# Altium schematic sheet 05_interconnection.SchDoc(05_interconnection)
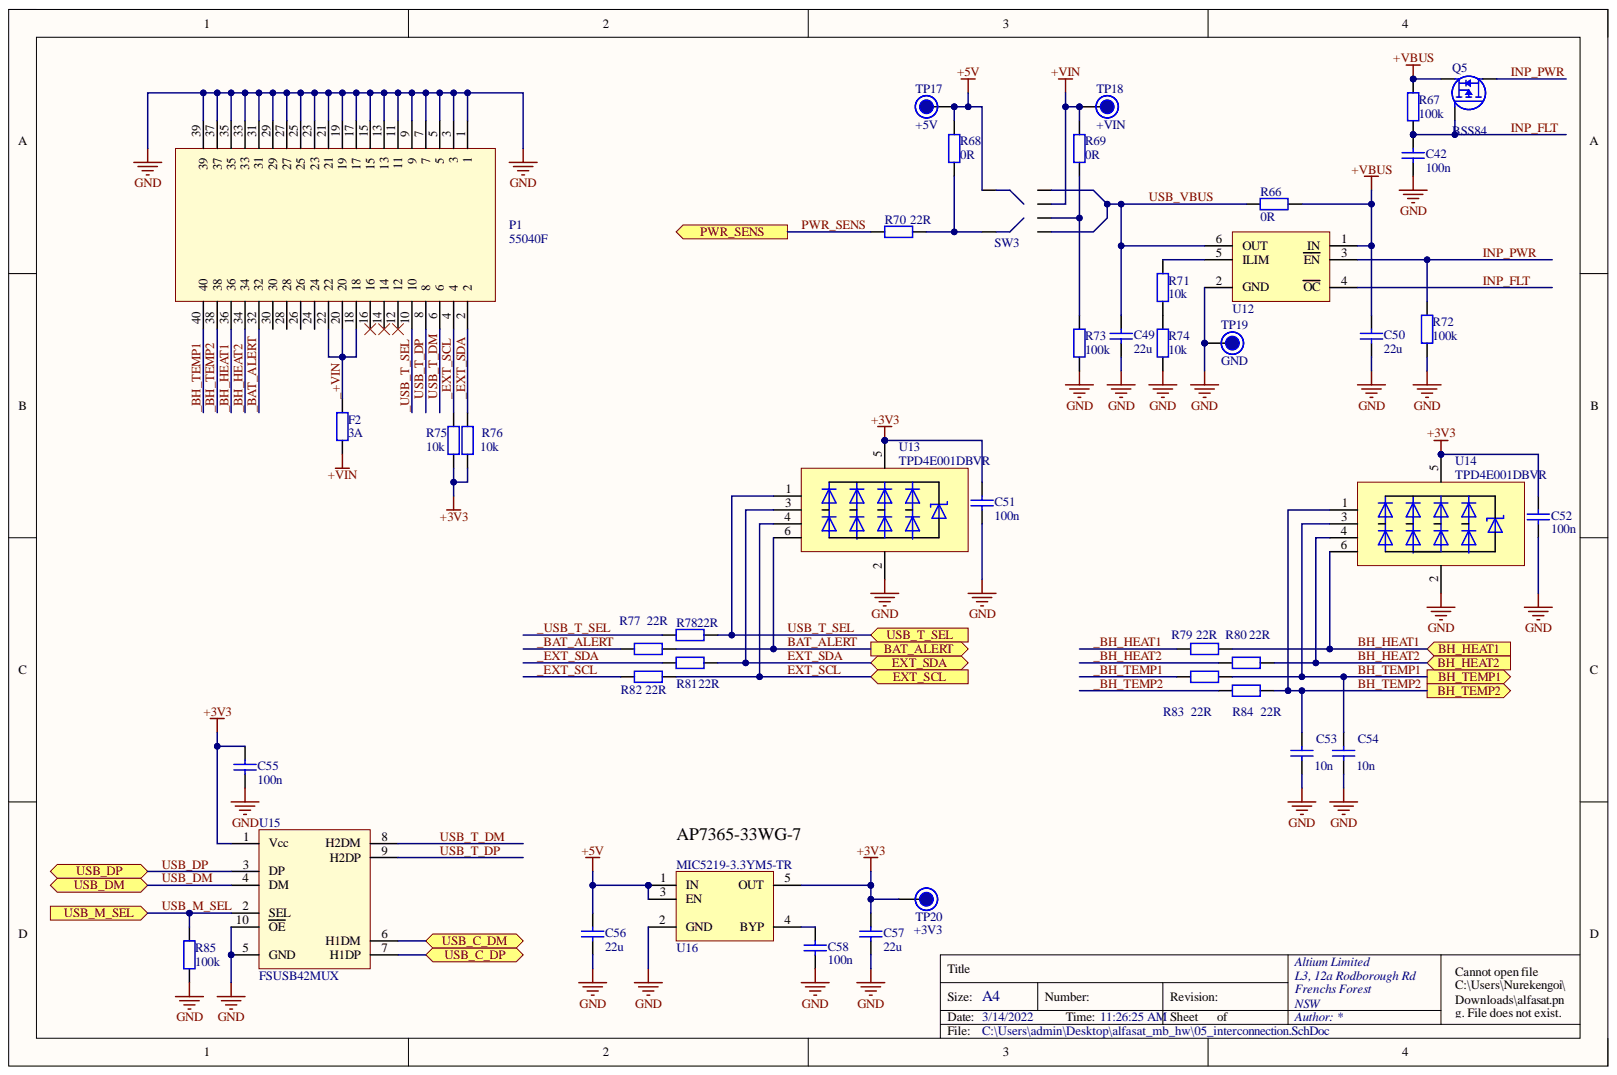
[diagram p0: 05_interconnection.SchDoc]

## Parts
C42  100n  [C0603_IPC_MD]
C49  22u  [C0805_IPC_MD]
C50  22u  [C0805_IPC_MD]
C51  100n  [C0603_IPC_MD]
C52  100n  [C0603_IPC_MD]
C53  10n  [C0603_IPC_MD]
C54  10n  [C0603_IPC_MD]
C55  100n  [C0603_IPC_MD]
C56  22u  [C0805_IPC_MD]
C57  22u  [C0805_IPC_MD]
C58  100n  [C0603_IPC_MD]
F2  3A  [F0603_IPC_MD]
P1  55040F  [HEADER_40PIN_12MM2]
Q5  BSS84  [SOT23]
R66  0R  [R0603_IPC_MD]
R67  100k  [R0603_IPC_MD]
R68  0R  [R0603_IPC_MD]
R69  0R  [R0603_IPC_MD]
R70  22R  [R0603_IPC_MD]
R71  10k  [R0603_IPC_MD]
R72  100k  [R0603_IPC_MD]
R73  100k  [R0603_IPC_MD]
R74  10k  [R0603_IPC_MD]
R75  10k  [R0603_IPC_MD]
R76  10k  [R0603_IPC_MD]
R77  22R  [R0603_IPC_MD]
R78  22R  [R0603_IPC_MD]
R79  22R  [R0603_IPC_MD]
R80  22R  [R0603_IPC_MD]
R81  22R  [R0603_IPC_MD]
R82  22R  [R0603_IPC_MD]
R83  22R  [R0603_IPC_MD]
R84  22R  [R0603_IPC_MD]
R85  100k  [R0603_IPC_MD]
SW3  K3-2245D-L1  [SWITCH_50mA_25V_V2]  (value not drawn)
TP17  +5V  [TestPoint_1M0]  (no BOM)
TP18  +VIN  [TestPoint_1M0]  (no BOM)
TP19  GND  [TestPoint_1M0]  (no BOM)
TP20  +3V3  [TestPoint_1M0]  (no BOM)
U12  BD2243G-GTR  [SOT23-6]  (value not drawn)
U13  TPD4E001DBVR  [SOT23-6]
U14  TPD4E001DBVR  [SOT23-6]
U15  FSUSB42MUX  [MSOP-10]
U16  MIC5219-3.3YM5-TR  [SOT23-5_(MT)]

## Ports
BAT_ALERT, BH_HEAT1, BH_HEAT2, BH_TEMP1, BH_TEMP2, EXT_SCL, EXT_SDA, PWR_SENS, USB_C_DM, USB_C_DP, USB_DM, USB_DP, USB_M_SEL, USB_T_SEL

## Nets
+3V3: C51.1 C52.1 C55.1 C57.1 R75.1 R76.1 TP20.0 U13.5 U14.5 U15.1(Vcc) U16.5(OUT)
+5V: C56.1 R68.2 SW3.2 TP17.0 U16.1(IN) U16.3(EN)
+VBUS: C50.1 Q5.2 R66.2 R67.2 U12.1(IN)
+VIN: F2.2 R69.2 SW3.3 TP18.0
GND: C42.2 C49.2 C50.2 C51.2 C52.2 C53.1 C54.1 C55.2 C56.2 C57.2 C58.2 P1.1 P1.3 P1.5 P1.7 P1.9 P1.11 P1.13 P1.15 P1.17 P1.19 P1.21 P1.23 P1.25 P1.27 P1.29 P1.31 P1.33 P1.35 P1.37 P1.39 R72.1 R73.1 R74.1 R85.1 TP19.0 U12.2(GND) U13.2 U14.2 U15.5(GND) U15.10(OE) U16.2(GND)
_+VIN: F2.1 P1.18 P1.20 P1.22
_BAT_ALERT: P1.32 R77.2
_BH_HEAT1: P1.36 R79.1
_BH_HEAT2: P1.34 R80.1
_BH_TEMP1: P1.40 R83.1
_BH_TEMP2: P1.38 R84.1
_EXT_SCL: P1.4 R75.2 R82.1
_EXT_SDA: P1.2 R76.2 R81.1
_USB_T_SEL: P1.10 R78.1
BAT_ALERT: R77.1 U13.6 ; port BAT_ALERT
BH_HEAT1: R79.2 U14.6 ; port BH_HEAT1
BH_HEAT2: R80.2 U14.4 ; port BH_HEAT2
BH_TEMP1: C54.2 R83.2 U14.3 ; port BH_TEMP1
BH_TEMP2: C53.2 R84.2 U14.1 ; port BH_TEMP2
EXT_SCL: R82.2 U13.4 ; port EXT_SCL
EXT_SDA: R81.2 U13.3 ; port EXT_SDA
INP_FLT: C42.1 Q5.1 R67.1 U12.4(OC)
INP_PWR: Q5.3 R72.2 U12.3(EN)
PWR_SENS: R70.2 ; port PWR_SENS
USB_C_DM: U15.6(H1DM) ; port USB_C_DM
USB_C_DP: U15.7(H1DP) ; port USB_C_DP
USB_DM: U15.4(DM) ; port USB_DM
USB_DP: U15.3(DP) ; port USB_DP
USB_M_SEL: R85.2 U15.2(SEL) ; port USB_M_SEL
USB_T_DM: P1.6 U15.8(H2DM)
USB_T_DP: P1.8 U15.9(H2DP)
USB_T_SEL: R78.2 U13.1 ; port USB_T_SEL
USB_VBUS: C49.1 R66.1 SW3.1 SW3.4 U12.6(OUT)
NetC58_1: C58.1 U16.4(BYP)
NetR68_1: R68.1 R70.1 SW3.5
NetR69_1: R69.1 R73.2 SW3.6
NetR71_1: R71.1 U12.5(ILIM)
NetR71_2: R71.2 R74.2

Not connected: P1 12 14 16 24 26 28 30

## Library data of the parts
C42 C51 C52 C55 C58 = CL10B104KO8NNNC: CAP CER 0.1UF 16V X7R 0603; Manufacturer: Samsung Electro-Mechanics; Component Kind: Standard; Dielectric: X7R; Manufacturer Part Number: CL10B104KO8NNNC; Supplier 1: Digi-Key; Supplier Part Number 1: 1276-1005-1-ND; Value: 100n; Voltage: 16V; Library Name: alfasat_parts_lib.IntLib
C49 C50 C56 C57 = CL21A226MOCLRNC: CAP CER 22UF 16V X5R 0805; Manufacturer: Samsung Electro-Mechanics; Component Kind: Standard; Dielectric: X5R; Manufacturer Part Number: CL21A226MOCLRNC; Supplier 1: Digi-Key; Supplier Part Number 1: 1276-6780-1-ND; Value: 22u; Voltage: 16V; Library Name: alfasat_parts_lib.IntLib
C53 C54 = CL10B103KB8NNNC: CAP CER 0.01UF 50V X7R 0603; Manufacturer: Samsung Electro-Mechanics; Component Kind: Standard; Dielectric: X7R; Manufacturer Part Number: CL10B103KB8NNNC; Supplier 1: Digi-Key; Supplier Part Number 1: 1276-1009-1-ND; Value: 10n; Voltage: 50V; Library Name: alfasat_parts_lib.IntLib
F2 = C2Q3: FUSE BRD MNT 3A 32VAC 63VDC 0603; Manufacturer: Bel Fuse Inc.; Component Kind: Standard; Manufacturer Part Number: C2Q3; Supplier 1: Digi-Key; Supplier Part Number 1: 507-1096-1-ND; Value: 3A; Library Name: alfasat_parts_lib.IntLib
P1 = 55040F: CONN HEADER R/A 40POS 2.54MM; Manufacturer: On Shore Technology Inc.; Component Kind: Standard; Manufacturer Part Number: 55040F; Supplier 1: LCSC; Supplier Part Number 1: C35165; Library Name: alfasat_parts_lib.IntLib
Q5 = BSS84: MOSFET P-CH 50V 130MA SOT23-3; Manufacturer: Diodes Inc.; Component Kind: Standard; Manufacturer Part Number: BSS84; Supplier 1: Digi-Key; Supplier Part Number 1: BSS84-FDICT-ND; Library Name: alfasat_parts_lib.IntLib
R66 R68 R69 = CR0603-J/-000ELF: RES SMD 0 OHM JUMPER 1/10W 0603; Manufacturer: Bourns Inc.; Tolarance: 1%; Component Kind: Standard; Manufacturer Part Number: CR0603-J/-000ELF; Supplier 1: Digi-Key; Supplier Part Number 1: CR0603-J/-000ELFCT-ND; Value: 0R; Library Name: alfasat_parts_lib.IntLib
R67 R72 R73 R85 = RMCF0603FT100K: RES 100K OHM 1% 1/10W 0603; Manufacturer: Bourns Inc.; Component Kind: Standard; Manufacturer Part Number: RMCF0603FT100K; Supplier 1: Digi-Key; Supplier Part Number 1: RMCF0603FT100KCT-ND; Tolarance: 1%; Value: 100k; Library Name: alfasat_parts_lib.IntLib
R70 R77-R84 = CR0603-JW-220ELF: RES SMD 22 OHM 5% 1/10W 0603; Manufacturer: Bourns Inc.; Component Kind: Standard; Manufacturer Part Number: CR0603-JW-220ELF; Supplier 1: Digi-Key; Supplier Part Number 1: CR0603-JW-220ELFCT-ND; Tolarance: 5%; Value: 22R; Library Name: alfasat_parts_lib.IntLib
R71 R74-R76 = CR0603-JW-10k: RES 10K OHM 1% 1/10W 0603; Manufacturer: Stackpole Electronics Inc; Manufacturer Part Number: RMCF0603FT10K0; Component Kind: Standard; Supplier 1: Digi-Key; Supplier Part Number 1: RMCF0603FT10K0CT-ND; Tolarance: ±1%; Value: 10k; Library Name: alfasat_parts_lib.IntLib
SW3 = K3-2245D-L1: DPDT On-On Through Hole Toggle Switches RoHS; Manufacturer: Korean Hroparts Elec; Component Kind: Standard; Manufacturer Part Number: K3-2245D-L1; Supplier 1: LCSC; Supplier Part Number 1: C223865; Library Name: alfasat_parts_lib.IntLib
TP17-TP20 = TP: Test Point; Library Name: alfasat_parts_lib.IntLib
U12 = BD2243G-GTR: IC PWR SWITCH N-CHAN 1:1 6SSOP; Manufacturer: ROHM Semicon; Component Kind: Standard; Manufacturer Part Number: BD2243G-GTR; Supplier 1: LCSC; Supplier Part Number 1: C84988; Library Name: alfasat_parts_lib.IntLib
U13 U14 = TPD4E001DBVR: 4x TVS DIODE 5.5V SOT23-6; Manufacturer: TI; Component Kind: Standard; Manufacturer Part Number: TPD4E001DBVR; Supplier 1: Digi-Key; Supplier Part Number 1: 296-28203-1-ND; Library Name: alfasat_parts_lib.IntLib
U15 = FSUSB42MUX: IC USB SWITCH DPDT 10MSOP; Manufacturer: ON Semiconductor; Component Kind: Standard; Manufacturer Part Number: FSUSB42MUX; Supplier 1: Digi-Key; Supplier Part Number 1: FSUSB42MUXCT-ND; Library Name: alfasat_parts_lib.IntLib
U16 = MIC5219-3.3YM5-TR: IC REG LINEAR 3.3V 500MA SOT23-5; Manufacturer: Microchip Technology; Component Kind: Standard; Manufacturer Part Number: MIC5219-3.3YM5-TR; Supplier 1: Digi-Key; Supplier Part Number 1: 576-1281-1-ND; Library Name: alfasat_parts_lib.IntLib
